# Altium schematic sheet Sheet4.SchDoc(Sheet4)
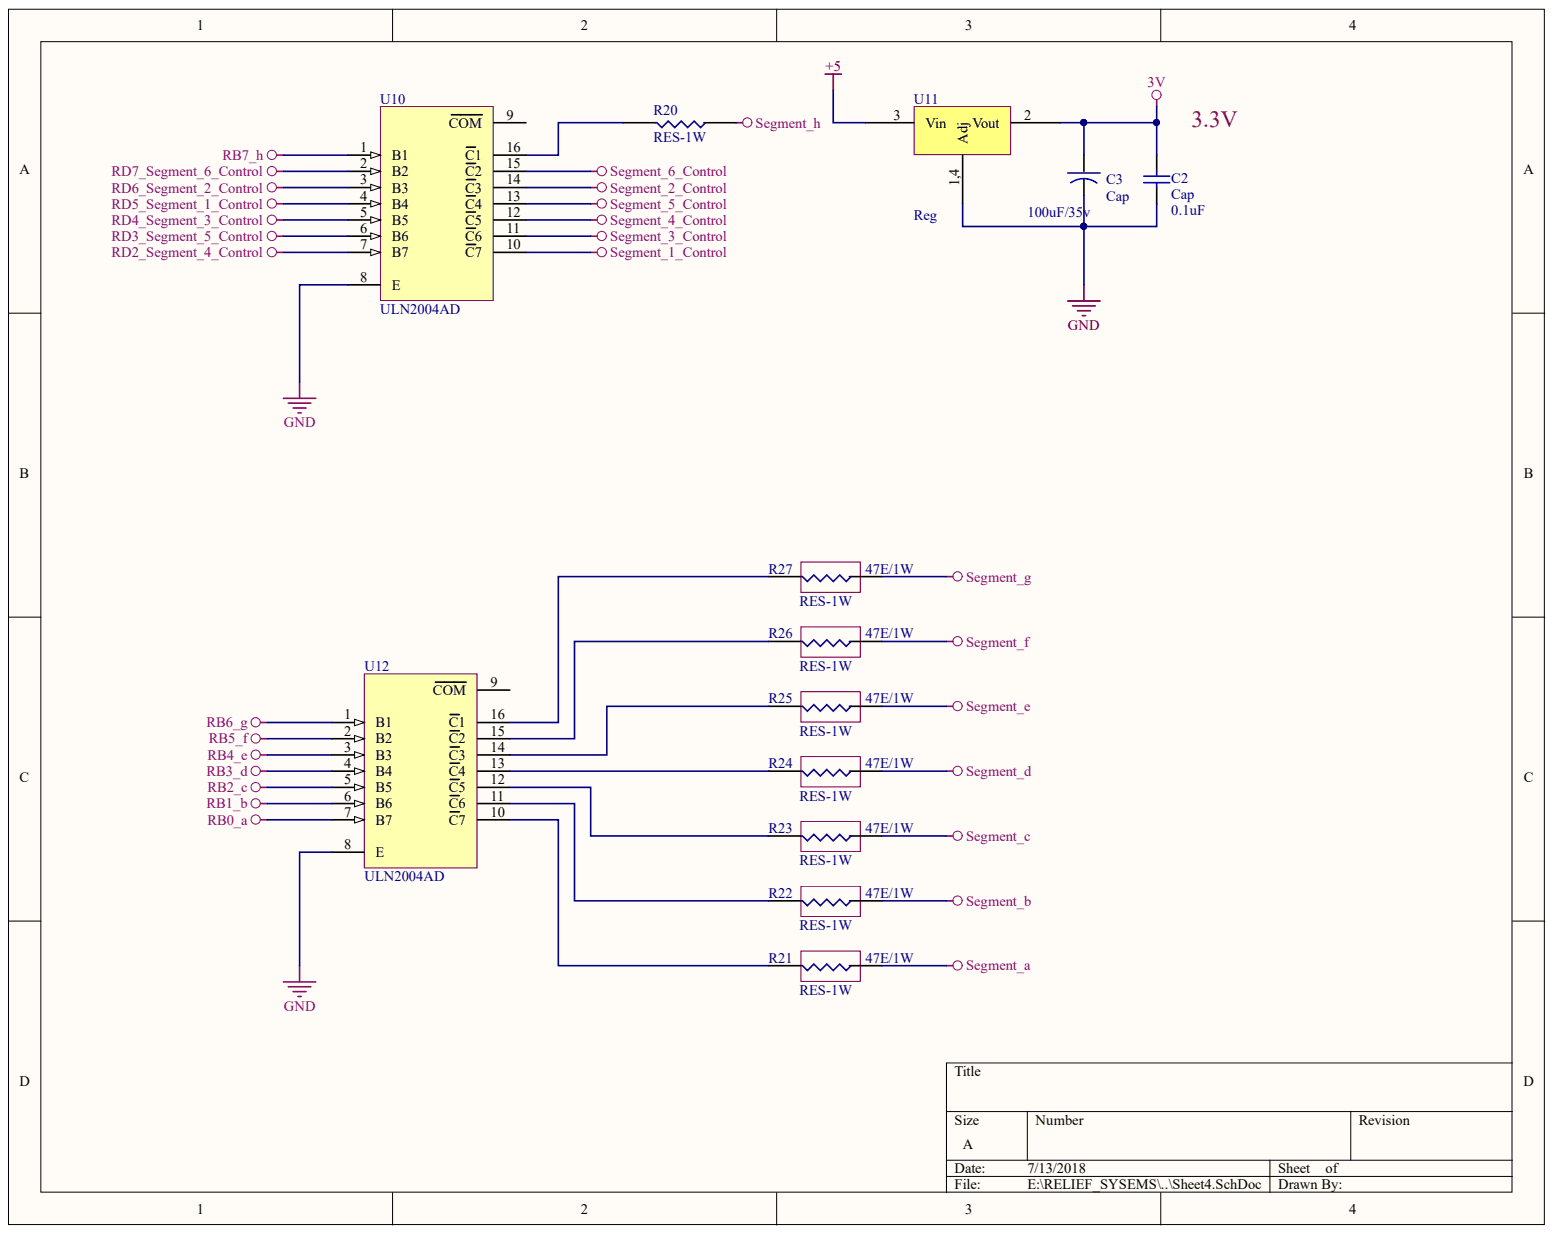
[diagram p0: Sheet4.SchDoc]

## Parts
C2  Cap  [RES0805]
C3  Cap  value 100uF/35v  [Capacitor10UF/63V]
R20  RES-1W  [RES-1W]
R21  RES-1W  value 47E/1W  [RES-1W]
R22  RES-1W  value 47E/1W  [RES-1W]
R23  RES-1W  value 47E/1W  [RES-1W]
R24  RES-1W  value 47E/1W  [RES-1W]
R25  RES-1W  value 47E/1W  [RES-1W]
R26  RES-1W  value 47E/1W  [RES-1W]
R27  RES-1W  value 47E/1W  [RES-1W]
U10  ULN2004AD  [D016_N]
U11  Reg  [LM1117]
U12  ULN2004AD  [D016_N]

## Nets
3V: C2.2 C3.1 U11.2
+5: U11.3
GND: C2.1 C3.2 U10.8(E) U11.1,4 U12.8(E)
RB0_A: U12.7(B7)
RB1_B: U12.6(B6)
RB2_C: U12.5(B5)
RB3_D: U12.4(B4)
RB4_E: U12.3(B3)
RB5_F: U12.2(B2)
RB6_G: U12.1(B1)
RB7_H: U10.1(B1)
RD2_SEGMENT_4_CONTROL: U10.7(B7)
RD3_SEGMENT_5_CONTROL: U10.6(B6)
RD4_SEGMENT_3_CONTROL: U10.5(B5)
RD5_SEGMENT_1_CONTROL: U10.4(B4)
RD6_SEGMENT_2_CONTROL: U10.3(B3)
RD7_SEGMENT_6_CONTROL: U10.2(B2)
SEGMENT_1_CONTROL: U10.10(C7)
SEGMENT_2_CONTROL: U10.14(C3)
SEGMENT_3_CONTROL: U10.11(C6)
SEGMENT_4_CONTROL: U10.12(C5)
SEGMENT_5_CONTROL: U10.13(C4)
SEGMENT_6_CONTROL: U10.15(C2)
SEGMENT_A: R21.2
SEGMENT_B: R22.2
SEGMENT_C: R23.2
SEGMENT_D: R24.2
SEGMENT_E: R25.2
SEGMENT_F: R26.2
SEGMENT_G: R27.2
SEGMENT_H: R20.2
NetR20_1: R20.1 U10.16(C1)
NetR21_1: R21.1 U12.10(C7)
NetR22_1: R22.1 U12.11(C6)
NetR23_1: R23.1 U12.12(C5)
NetR24_1: R24.1 U12.13(C4)
NetR25_1: R25.1 U12.14(C3)
NetR26_1: R26.1 U12.15(C2)
NetR27_1: R27.1 U12.16(C1)

Not connected: U10 9(COM); U12 9(COM)

## Library data of the parts
C2 = CAP_104_SMD: : 0.1uF; Component Kind: Standard; Description: Cap_104_SMD; Library Name: Dinesh_New_Integrated_Library.IntLib
C3 = Cap-10uf/63V: Cap10uf/63V; Value: 100uF/35v; Component Kind: Standard; Library Name: VIJAYIntegrated_Library.IntLib
U10 U12 = ULN2004AD: Darlington Transistor Array; Code_IPC: SOIC127P600-16; Component Kind: Standard; ComponentLink1Description: Manufacturer Link; ComponentLink1URL: http://www.ti.com/; ComponentLink2Description: Datasheet; ComponentLink2URL: http://www-s.ti.com/sc/ds/uln2004a.pdf; DatasheetVersion: Sep-1986; LatestRevisionDate: 13-Apr-2006; LatestRevisionNote: IPC-7351 Footprint Added.; Library Name: TI Interface Darlington Driver.IntLib; PackageDescription: 16-Pin Small Outline Integrated Circuit 1.27 mm Pitch; PackageReference: D016; PackageVersion: Jan-1998; PCB3D: D016; Published: 15-May-2002; Publisher: Altium Limited
U11 = LM1117: Component Kind: Standard; Library Name: Dinesh_New_Integrated_Library.IntLib
R20-R27 = RES-1W: Component Kind: Standard; Library Name: VIJAYIntegrated_Library.IntLib
